# Altium schematic sheet amplifier.SchDoc(amplifier)
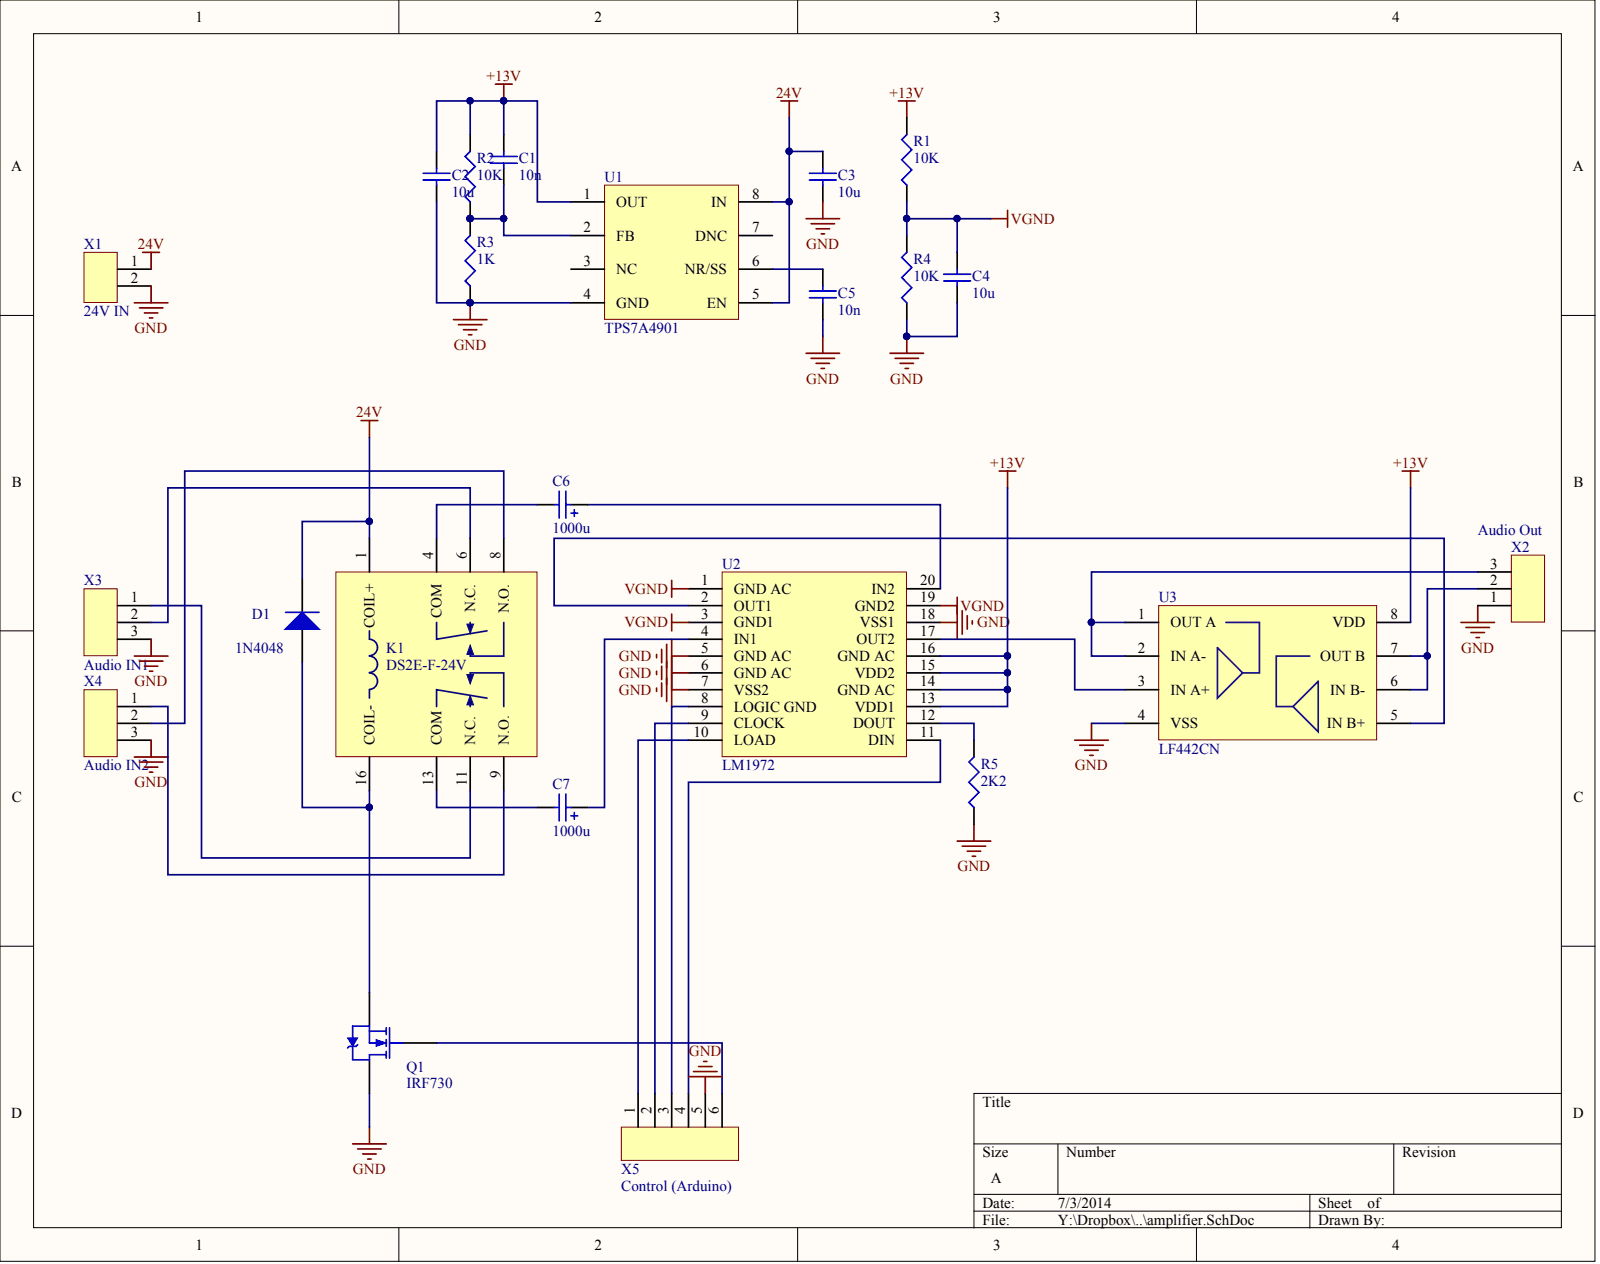
[diagram p0: amplifier.SchDoc]

## Parts
C1  10n  [CAPC3216X17N]
C2  10u  [CAPC3216X17N]
C3  10u  [CAPC3216X17N]
C4  10u  [CAPC3216X17N]
C5  10n  [CAPC3216X17N]
C6  1000u  [Radial_0254_0381X0900]
C7  1000u  [Radial_0254_0381X0900]
D1  1N4048  [DO-35]
K1  DS2E-F-24V  [DS2E-F-DC24V]
Q1  IRF730  [TO-220AB]
R1  10K  [RESC2012X06M]
R2  10K  [RESC2012X06M]
R3  1K  [RESC3216X07N]
R4  10K  [RESC2012X06M]
R5  2K2  [RESC2012X06N]
U1  TPS7A4901  [MSOP-8]
U2  LM1972  [SOIC-20_0127_7.5mm]
U3  LF442CN  [DIP-08_0254_0762_0420]
X1  24V IN  [CON_1X02S_0254]
X2  Audio Out  [CON_1X03S_0254]
X3  Audio IN1  [CON_1X03S_0254]
X4  Audio IN2  [CON_1X03S_0254]
X5  Control (Arduino)  [CON_1X06S_0254]

## Nets
24V: C3.2 D1.2 K1.1(COIL+) U1.5(EN) U1.8(IN) X1.1
+13V: C1.2 C2.2 R1.2 R2.2 U1.1(OUT) U2.13(VDD1) U2.14(GND_AC) U2.15(VDD2) U2.16(GND_AC) U3.8(VDD)
GND: C2.1 C3.1 C4.1 C5.1 Q1.2 R3.1 R4.1 R5.1 U1.4(GND) U2.5(GND_AC) U2.6(GND_AC) U2.7(VSS2) U2.18(VSS1) U3.4(VSS) X1.2 X2.1 X3.3 X4.3 X5.5
VGND: C4.2 R1.1 R4.2 U2.1(GND_AC) U2.3(GND1) U2.19(GND2)
NetC1_1: C1.1 R2.1 R3.2 U1.2(FB)
NetC5_2: C5.2 U1.6(NR/SS)
NetC6_1: C6.1 U2.20(IN2)
NetC6_2: C6.2 K1.4(COM)
NetC7_1: C7.1 U2.4(IN1)
NetC7_2: C7.2 K1.13(COM)
NetD1_1: D1.1 K1.16(COIL-) Q1.3
NetK1_6: K1.6(N.C.) X3.2
NetK1_8: K1.8(N.O.) X4.2
NetK1_9: K1.9(N.O.) X4.1
NetK1_11: K1.11(N.C.) X3.1
NetQ1_1: Q1.1 X5.6
NetR5_2: R5.2 U2.12(DOUT)
NetU2_2: U2.2(OUT1) U3.5(IN_B+)
NetU2_8: U2.8(LOGIC_GND) X5.3
NetU2_9: U2.9(CLOCK) X5.2
NetU2_10: U2.10(LOAD) X5.1
NetU2_11: U2.11(DIN) X5.4
NetU2_17: U2.17(OUT2) U3.3(IN_A+)
NetU3_1: U3.1(OUT_A) U3.2(IN_A-) X2.3
NetU3_6: U3.6(IN_B-) U3.7(OUT_B) X2.2

Not connected: U1 3(NC) 7(DNC)

## Library data of the parts
C1 C5 = C1206C103K: CAP CER 10NF 50V 10% X7R 1206; Manufacturer: Yageo; Manufacturer Part Number: CC1206KRX7R9BB103; Capacitance: 10000pF; Applications: General Purpose; Category: Capacitors; Component Kind: Standard; ComponentLink1Description: http://www.yageo.com/documents/recent/UPY-GPHC_X7R_6.3V-to-50V_8.pdf; ComponentLink1URL: http://www.yageo.com/documents/recent/UPY-GPHC_X7R_6.3V-to-50V_8.pdf; Features: -; Height - Seated (Max): -; Lead Spacing: -; Lead Style: -; Library Name: BaseLab.IntLib; Mounting Type: Surface Mount, MLCC; Operating Temperature: -55°C ~ 125°C; Package / Case: 1206 (3216 Metric); Packaging: Cut Tape (CT); Pricing 1: 1=0.13, 10=0.092, 25=0.0688, 100=0.0436, 250=0.03672, 500=0.03076, 1000=0.02525 (USD); Ratings: -; RoHS: RoHS Compliant; Simulation: Capacitor; Size / Dimension: 0.126" L x 0.063" W (3.20mm x 1.60mm); Stock 1: 405160; Supplier 1: Digi-Key; Supplier Part Number 1: 311-1174-1-ND; Temperature Coefficient: X7R; Thickness (Max): 0.033" (0.85mm); Tolerance: ±10%; Value: 10n; Voltage - Rated: 50V
C2-C4 = C1206C104K: CAP CER 100NF 50V 10% X7R 1206; Manufacturer: Kemet; Manufacturer Part Number: C1206C104K5RACTU; Capacitance: 0.1µF; Applications: General Purpose; Category: Capacitors; Component Kind: Standard; ComponentLink1Description: http://www.kemet.com/datasheets&C1206C104K5RACTU; ComponentLink1URL: http://www.kemet.com/datasheets&C1206C104K5RACTU; Features: -; Height - Seated (Max): -; Lead Spacing: -; Lead Style: -; Library Name: BaseLab.IntLib; Mounting Type: Surface Mount, MLCC; Operating Temperature: -55°C ~ 125°C; Package / Case: 1206 (3216 Metric); Packaging: Cut Tape (CT); Pricing 1: 1=0.1, 10=0.072, 50=0.039, 100=0.033, 250=0.027, 500=0.0231, 1000=0.018 (USD); Ratings: -; RoHS: RoHS Compliant; Simulation: Capacitor; Size / Dimension: 0.126" L x 0.063" W (3.20mm x 1.60mm); Stock 1: 620114; Supplier 1: Digi-Key; Temperature Coefficient: X7R; Thickness (Max): 0.035" (0.88mm); Tolerance: ±10%; Value: 10u; Voltage - Rated: 50V
C6 C7 = CA-1CM102: CAP ALUM 1000UF 16V 20% RADIAL; Manufacturer: Panasonic Electronic Components; Manufacturer Part Number: ECA-1CM102B; Capacitance: 1000µF; Lifetime @ Temp.: 2000 Hrs @ 85°C; Ripple Current: 680mA; Applications: General Purpose; Category: Capacitors; Component Kind: Standard; ComponentLink1Description: http://industrial.panasonic.com/www-cgi/jvcr13pz.cgi?E+PZ+3+ABA0012+ECA1CM102B+7+WW; ComponentLink1URL: http://industrial.panasonic.com/www-cgi/jvcr13pz.cgi?E+PZ+3+ABA0012+ECA1CM102B+7+WW; ESR (Equivalent Series Resistance): -; Height - Seated (Max): 0.669" (17.00mm); Impedance: -; Lead Spacing: 0.197" (5.00mm); Library Name: BaseLab.IntLib; Mounting Type: Through Hole; Operating Temperature: -40°C ~ 85°C; Package / Case: Radial, Can; Packaging: Tape & Box (TB); Pricing 1: 500=0.1596, 1000=0.13566, 5000=0.1197, 10000=0.11172, 25000=0.10773 (USD); RoHS: RoHS Compliant; Simulation: Capacitor; Size / Dimension: 0.394" Dia (10.00mm); Stock 1: 118500; Supplier 1: Digi-Key; Surface Mount Land Size: -; Tolerance: ±20%; Type: -; Value: 1000u; Voltage Rating: 16V
D1 = 1N4048: 275 Amp Avg Power Silicon Rectifier Diode; Note: No PCB Footprint Available; Component Kind: Standard; ComponentLink1Description: Manufacturer Link; ComponentLink1URL: http://www.irf.com/; ComponentLink2Description: Datasheet; ComponentLink2URL: http://www.irf.com/product-info/datasheets/data/1n4044.pdf; DatasheetVersion: PD-2.091; LatestRevisionDate: 17-Jul-2002; LatestRevisionNote: Re-released for DXP Platform.; PackageReference: DO-205AB; Published: 8-Jun-2000; Signal Integrity: 1N4048; Simulation: 1N4048; Library Name: IR Rectifier - Standard Recovery.IntLib; Publisher: Altium Limited
K1 = DS2E-F-DC24V: Relay 24V; Manufacturer: Panasonic Electric Works; Manufacturer Part Number: DS2E-S-DC24V; Coil Current: 8.3mA; Coil Power: 200 mW; Coil Resistance: 2.88 kOhms; Coil Type: Non Latching; Coil Voltage: 24VDC; Contact Form: DPDT (2 Form C); Contact Rating (Current): 2A; Operate Time: 10ms; Relay Type: General Purpose; Release Time: 5ms; Switching Voltage: 250VAC, 220VDC - Max; Turn Off Voltage (Min): 2.4 VDC; Turn On Voltage (Max): 16.8 VDC; Category: Relays; Component Kind: Standard; ComponentLink1Description: http://pewa.panasonic.com/assets/pcsd/catalog/ds-catalog.pdf; ComponentLink1URL: http://pewa.panasonic.com/assets/pcsd/catalog/ds-catalog.pdf; Contact Material: Silver (Ag), Gold (Au); Features: Sealed - Amber; Library Name: BaseLab.IntLib; Mounting Type: Through Hole; Operating Temperature: -40°C ~ 70°C; Packaging: Tube; Pricing 2: 1=5.37, 25=4.9276, 50=4.38, 100=3.723, 500=3.285, 1000=3.1755, 5000=3.066, 10000=2.9127, 25000=2.847 (USD); RoHS: RoHS Compliant; Stock 2: 3240; Supplier 1: Digi-Key; Supplier 2: Digi-Key; Termination Style: PC Pin
Q1 = IRF730: HEXFET N-Channel Power MOSFET; Note: Pin 2 is electrically connected to pin 4.; Component Kind: Standard; ComponentLink1Description: Manufacturer Link; ComponentLink1URL: http://www.irf.com/; ComponentLink2Description: Datasheet; ComponentLink2URL: http://www.irf.com/product-info/datasheets/data/irf730.pdf; DatasheetVersion: PD-9.308K; LatestRevisionDate: 17-Jul-2002; LatestRevisionNote: Re-released for DXP Platform.; Library Name: IR Discrete MOSFET - Power.IntLib; PackageDescription: TO, Thru-Hole, Vertical, Heatsink Mounted; 3 In-Line Leads; Pitch 2.54 mm; PackageReference: TO-220AB; PackageVersion: Feb-1997; Published: 8-Jun-2000; Simulation: IRF730; Publisher: Altium Limited
R1 R2 R4 = RC0805FR-0710KL: RES 10K OHM 1/8W 1% 0805 SMD; Manufacturer: Yageo; Power (Watts): 0.125W, 1/8W; Temperature Coefficient: ±100ppm/°C; Category: Resistors; Component Kind: Standard; ComponentLink1Description: http://media.digikey.com/pdf/Data%20Sheets/Yageo%20PDFs/RC0805%20Pb%20Free.pdf; ComponentLink1URL: http://media.digikey.com/pdf/Data%20Sheets/Yageo%20PDFs/RC0805%20Pb%20Free.pdf; Composition: Thick Film; Features: -; Height: 0.024" (0.60mm); Library Name: BaseLab.IntLib; Manufacturer Part Number: RC0805FR-0710KL; Number of Terminations: 2; Package / Case: 0805 (2012 Metric); Packaging: Digi-Reel®; Pricing 1: 1=0.1, 10=0.019, 25=0.0148, 100=0.0089, 250=0.00764, 500=0.0054, 1000=0.00381, 2500=0.0033 (USD); Resistance (Ohms): 10k; RoHS: RoHS Compliant; Simulation: Resistor; Size / Dimension: 0.079" L x 0.049" W (2.00mm x 1.25mm); Stock 1: 3054580; Supplier 1: Digi-Key; Supplier Device Package: 0805; Tolerance: ±1%; Value: 10K
R3 = RC1206FR-071KL: RES 1.00K OHM 1/4W 1% 1206 SMD; Manufacturer: Yageo; Power (Watts): 0.25W, 1/4W; Temperature Coefficient: ±100ppm/°C; Category: Resistors; Component Kind: Standard; ComponentLink1Description: http://www.yageo.com/documents/recent/PYu-RC1206_51_RoHS_L-4.pdf; ComponentLink1URL: http://www.yageo.com/documents/recent/PYu-RC1206_51_RoHS_L-4.pdf; Composition: Thick Film; Features: -; Height: 0.026" (0.65mm); Library Name: BaseLab.IntLib; Manufacturer Part Number: RC1206FR-071KL; Number of Terminations: 2; Package / Case: 1206 (3216 Metric); Packaging: Cut Tape (CT); Pricing 1: 1=0.1, 10=0.03, 25=0.0228, 100=0.0139, 250=0.01196, 500=0.00846, 1000=0.00597, 2500=0.00518 (USD); Resistance (Ohms): 1k; RoHS: RoHS Compliant; Simulation: Resistor; Size / Dimension: 0.122" L x 0.063" W (3.10mm x 1.60mm); Stock 1: 459682; Supplier 1: Digi-Key; Supplier Device Package: 1206; Supplier Part Number 1: 311-1.00KFRCT-ND; Tolerance: ±1%; Value: 1K
R5 = RC0805FR-072K2L: RES 2.20K OHM 1/8W 1% 0805 SMD; Manufacturer: Yageo; Power (Watts): 0.125W, 1/8W; Resistance (Ohms): 2.2k; Temperature Coefficient: ±100ppm/°C; Category: Resistors; Component Kind: Standard; ComponentLink1Description: http://media.digikey.com/pdf/Data%20Sheets/Yageo%20PDFs/RC0805%20Pb%20Free.pdf; ComponentLink1URL: http://media.digikey.com/pdf/Data%20Sheets/Yageo%20PDFs/RC0805%20Pb%20Free.pdf; Composition: Thick Film; Features: -; Height: 0.024" (0.60mm); Library Name: BaseLab.IntLib; Manufacturer Part Number: RC0805FR-072K2L; Number of Terminations: 2; Package / Case: 0805 (2012 Metric); Packaging: Digi-Reel®; Pricing 1: 1=0.1, 10=0.019, 25=0.0148, 100=0.0089, 250=0.00764, 500=0.0054, 1000=0.00381, 2500=0.0033 (USD); RoHS: RoHS Compliant; Simulation: Resistor; Size / Dimension: 0.079" L x 0.049" W (2.00mm x 1.25mm); Stock 1: 328965; Supplier 1: Digi-Key; Supplier Device Package: 0805; Tolerance: ±1%; Value: 2K2
U1 = TPS7A4901: IC, ADJ LDO REG, 1.2V-33V, 0.15A, MSOP-8; Manufacturer: Texas Instruments; Manufacturer Part Number: TPS7A4901DGNR; Current - Limit (Min): 220mA; Current - Output: 150mA; Number of Regulators: 1; Regulator Topology: Positive Adjustable; Voltage - Dropout (Typical): 0.333V @ 150mA; Voltage - Input: 3 V ~ 35 V; Voltage - Output: 1.176 V ~ 33 V; Category: Integrated Circuits (ICs); Component Kind: Standard; ComponentLink1Description: http://www.ti.com/lit/ds/symlink/tps7a4901.pdf; ComponentLink1URL: http://www.ti.com/lit/ds/symlink/tps7a4901.pdf; Library Name: BaseLab.IntLib; Mounting Type: Surface Mount; Operating Temperature: -40°C ~ 125°C; Package / Case: 8-TSSOP, 8-MSOP (0.118", 3.00mm Width) Exposed Pad; Packaging: Tape & Reel (TR); Pricing 1: 2500=1.2375 (USD); RoHS: RoHS Compliant; Stock 1: 7500; Supplier 1: Digi-Key; Supplier Device Package: 8-MSOP-PowerPad; Supplier Part Number 1: 296-27751-2-ND
U3 = LF442CN: LF442 Dual Low Power JFET Input Operational Amplifier; Manufacturer: TEXAS INSTRUMENTS; Amplifier Case Style: DIP; Amplifier Type: JFET Operational; Bandwidth: 1; Base Number: 442; IC Temperature Range: Commercial; Input Offset Voltage Max: 7.5; No. of Amplifiers: 2; No. of Pins: 8; Supply Voltage + Nom: 15; Supply Voltage Max: 36; Supply Voltage Min: 10; Supply Voltage Range: 6V to 36; SVHC: No SVHC (16-Dec-2013); Component Kind: Standard; ComponentLink1Description: http://www.farnell.com/simulation/1745042.zip; ComponentLink1URL: http://www.farnell.com/simulation/1745042.zip; ComponentLink2Description: http://www.farnell.com/datasheets/78157.pdf; ComponentLink2URL: http://www.farnell.com/datasheets/78157.pdf; ComponentLink3Description: http://www.farnell.com/cad/1562079.zip; ComponentLink3URL: http://www.farnell.com/cad/1562079.zip; ComponentLink4Description: http://www.farnell.com/datasheets/1828886.pdf; ComponentLink4URL: http://www.farnell.com/datasheets/1828886.pdf; Device Marking: LF442; IC Generic Number: 442; Library Name: BaseLab.IntLib; Logic Function Number: 442; Manufacturer Part Number: LF442CN; MSL: MSL 1 - Unlimited; Op Amp Type: Low Power; Operating Temperature Max: 70; Operating Temperature Min: 0; Operating Temperature Range: 0°C to +70; Packaging: Each; Pricing 2: 1=1.24, 10=1.12, 100=1.06, 250=1.01, 500=0.98, 2000=0.336, 2000=0.332, 20000=0.328 (GBP); RoHS: YES; Slew Rate: 1; Stock 2: 445; Supplier 1: Farnell; Supplier 2: Farnell
X1 = HDR1x02: Stecker 2-Pin; Manufacturer: Sullins Connector Solutions; Manufacturer Part Number: PREC040SFAN-RC; Connector Type: Header, Unshrouded; Number of Positions: 40; Number of Positions Loaded: All; Pitch: 0.100" (2.54mm); Category: Connectors, Interconnects; Color: Black; Component Kind: Standard; ComponentLink1Description: http://media.digikey.com/PDF/Data%20Sheets/Sullins%20PDFs/xRxCzzzSxxN-RC_ST_11635-B.pdf; ComponentLink1URL: http://media.digikey.com/PDF/Data%20Sheets/Sullins%20PDFs/xRxCzzzSxxN-RC_ST_11635-B.pdf; Contact Finish: Tin; Contact Finish Thickness: -; Contact Mating Length: 0.318" (8.08mm); Contact Type: Male Pin; Fastening Type: -; Features: -; Library Name: BaseLab.IntLib; Mounting Type: Through Hole; Number of Rows: 1; Packaging: Bulk; Pricing 1: 1=0.58, 10=0.518, 100=0.4263, 500=0.33698, 1000=0.28928, 5000=0.2639, 10000=0.25375 (USD); RoHS: RoHS Compliant; Row Spacing: -; Stock 1: 1486; Supplier 1: Digi-Key; Termination: Solder
X2-X4 = HDR1x03: Stecker 3-Pin; Manufacturer: Sullins Connector Solutions; Manufacturer Part Number: PREC040SFAN-RC; Connector Type: Header, Unshrouded; Number of Positions: 40; Number of Positions Loaded: All; Pitch: 0.100" (2.54mm); Category: Connectors, Interconnects; Color: Black; Component Kind: Standard; ComponentLink1Description: http://media.digikey.com/PDF/Data%20Sheets/Sullins%20PDFs/xRxCzzzSxxN-RC_ST_11635-B.pdf; ComponentLink1URL: http://media.digikey.com/PDF/Data%20Sheets/Sullins%20PDFs/xRxCzzzSxxN-RC_ST_11635-B.pdf; Contact Finish: Tin; Contact Finish Thickness: -; Contact Mating Length: 0.318" (8.08mm); Contact Type: Male Pin; Fastening Type: -; Features: -; Library Name: BaseLab.IntLib; Mounting Type: Through Hole; Number of Rows: 1; Packaging: Bulk; Pricing 1: 1=0.58, 10=0.518, 100=0.4263, 500=0.33698, 1000=0.28928, 5000=0.2639, 10000=0.25375 (USD); RoHS: RoHS Compliant; Row Spacing: -; Stock 1: 1486; Supplier 1: Digi-Key; Termination: Solder
X5 = HDR1x06: Stecker 4-Pin; Manufacturer: Sullins Connector Solutions; Manufacturer Part Number: PREC040SFAN-RC; Connector Type: Header, Unshrouded; Number of Positions: 40; Number of Positions Loaded: All; Pitch: 0.100" (2.54mm); Category: Connectors, Interconnects; Color: Black; Component Kind: Standard; ComponentLink1Description: http://media.digikey.com/PDF/Data%20Sheets/Sullins%20PDFs/xRxCzzzSxxN-RC_ST_11635-B.pdf; ComponentLink1URL: http://media.digikey.com/PDF/Data%20Sheets/Sullins%20PDFs/xRxCzzzSxxN-RC_ST_11635-B.pdf; Contact Finish: Tin; Contact Finish Thickness: -; Contact Mating Length: 0.318" (8.08mm); Contact Type: Male Pin; Fastening Type: -; Features: -; Library Name: BaseLab.IntLib; Mounting Type: Through Hole; Number of Rows: 1; Packaging: Bulk; Pricing 1: 1=0.58, 10=0.518, 100=0.4263, 500=0.33698, 1000=0.28928, 5000=0.2639, 10000=0.25375 (USD); RoHS: RoHS Compliant; Row Spacing: -; Stock 1: 1486; Supplier 1: Digi-Key; Termination: Solder
U2 = LM1972: Component Kind: Standard; Library Name: BaseLab.IntLib; Supplier 1: Digi-Key
